# Altium schematic sheet Sheet1.SchDoc(Sheet1)
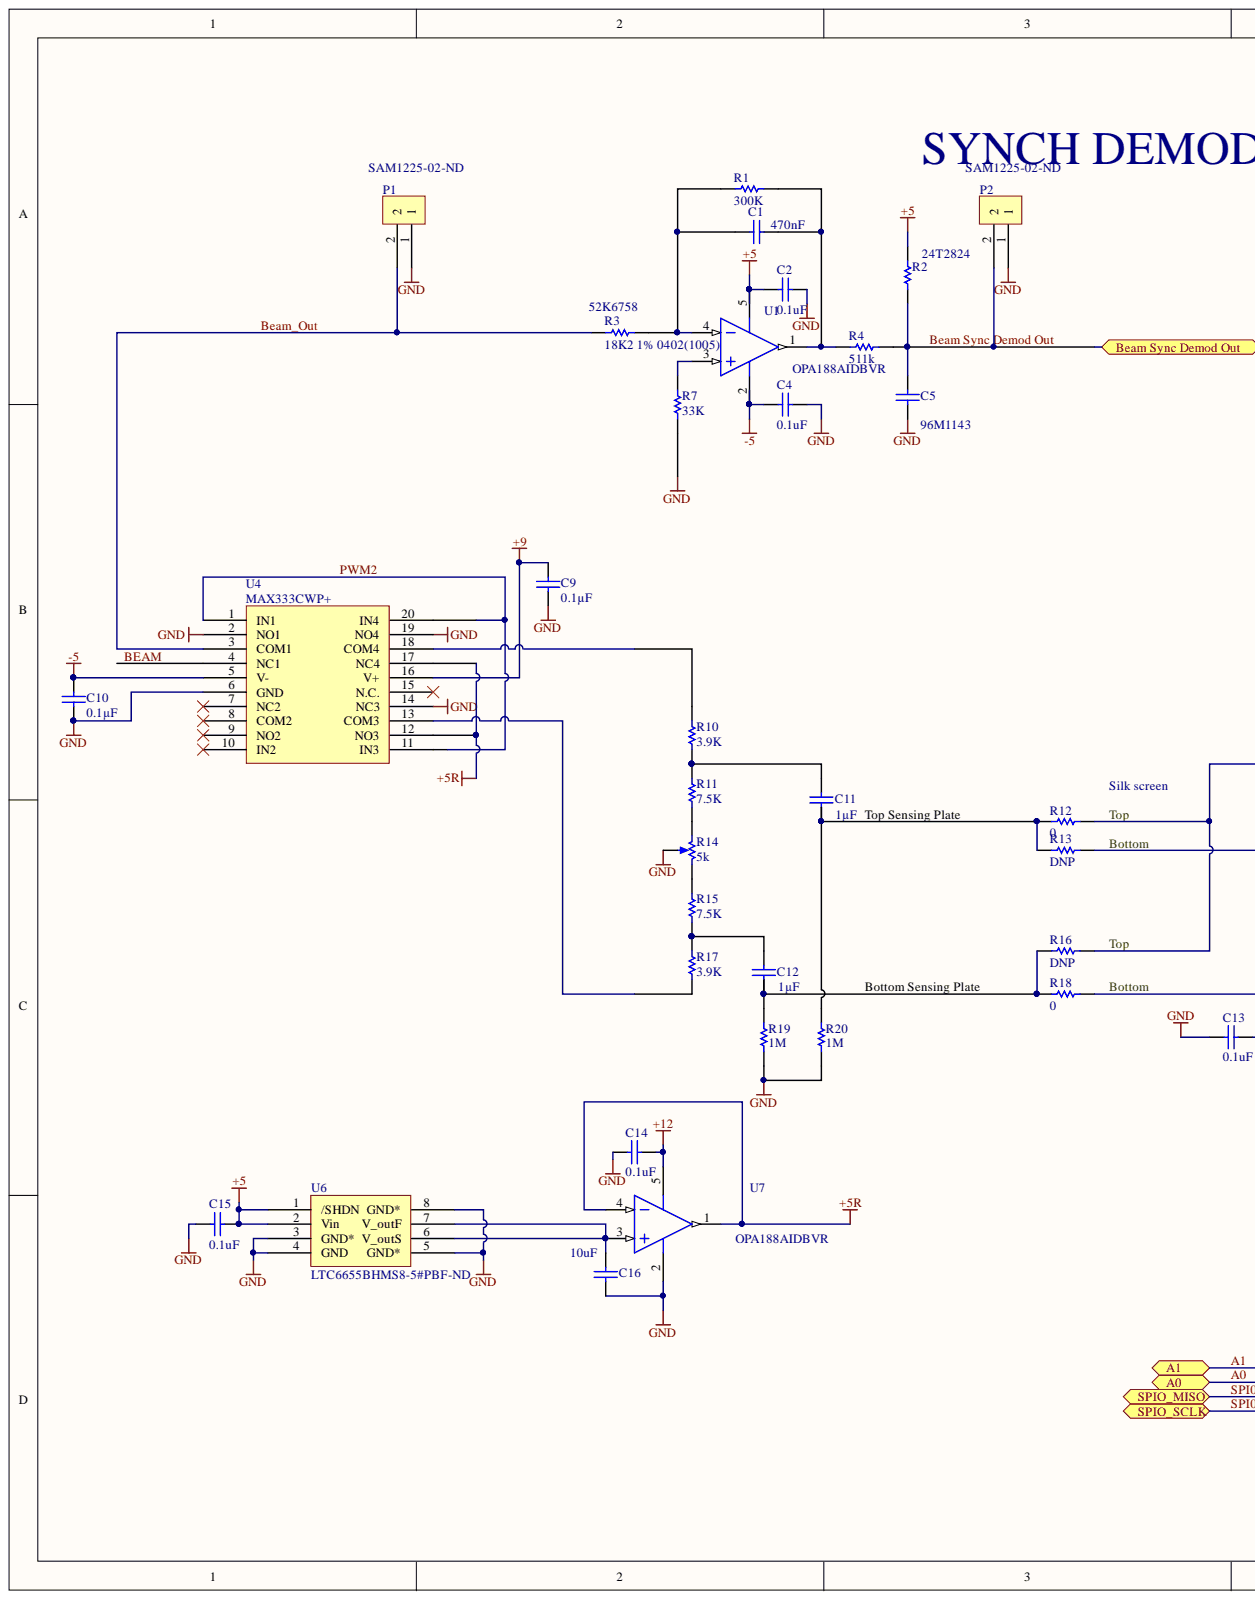
[diagram p0: Sheet1.SchDoc, left]
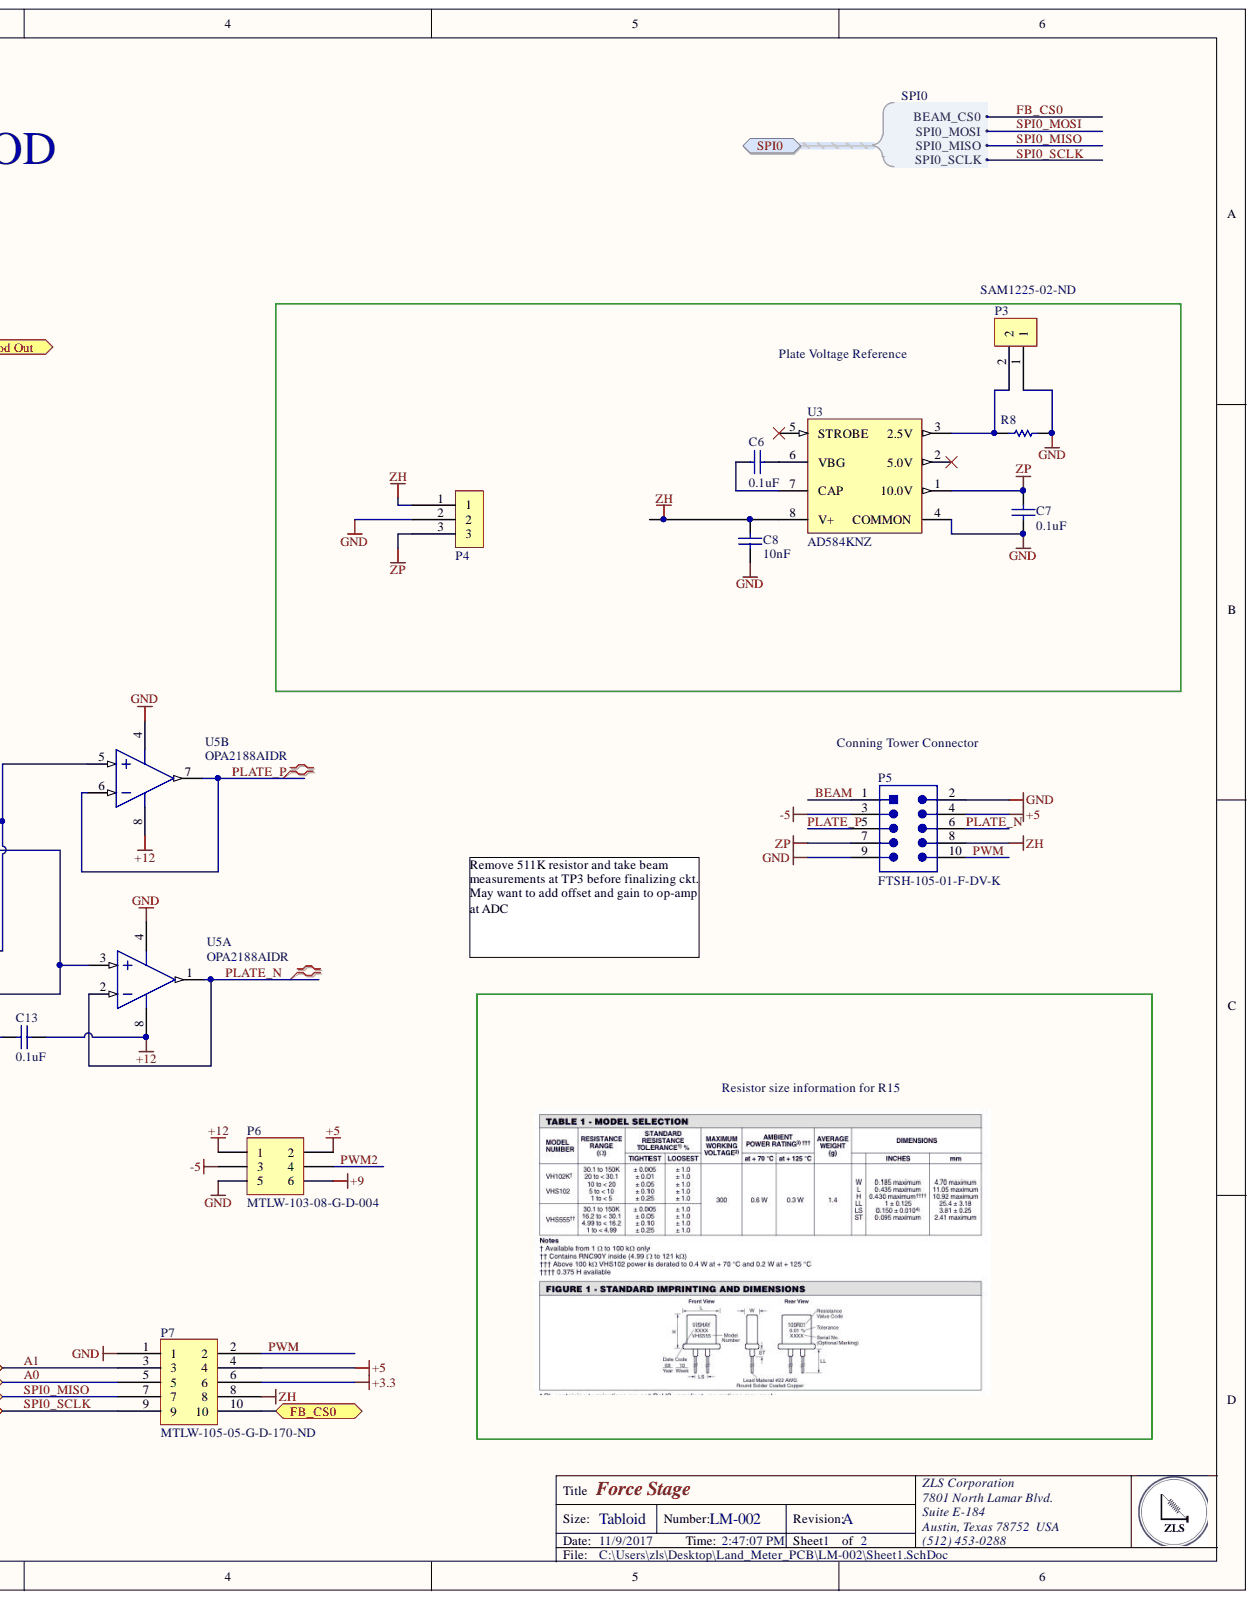
[diagram p1: Sheet1.SchDoc, right]

## Parts
C1  Capacitance: 470nF  [CAPC0402(1005)60_L]
C2  0.1uF  [CAPC0402(1005)60_L]
C4  0.1uF  [CAPC0402(1005)60_L]
C5  Supplier Part Number 1: 96M1143  [CAPC0402(1005)60_L]
C6  0.1uF  [CAPC0402(1005)60_L]
C7  0.1uF  [CAPC0402(1005)60_L]
C8  Capacitance: 10nF  [CAPC0805(2012)145_N]  (hidden comment: Multilayer Ceramic Capacitors MLCC - SMD/SMT 0.01uF 50volts 10% X7R)
C9  Capacitance: 0.1µF  [CAPC0805(2012)145_N]  (hidden comment: CAP CER 0.1UF 25V X7R 0805)
C10  Capacitance: 0.1µF  [CAPC0805(2012)145_N]  (hidden comment: CAP CER 0.1UF 25V X7R 0805)
C11  Capacitance: 1µF  [CAPC0805(2012)155_L]  (hidden comment: CAP CER 1UF 25V X7R 0805)
C12  Capacitance: 1µF  [CAPC0805(2012)155_L]  (hidden comment: CAP CER 1UF 25V X7R 0805)
C13  0.1uF  [CAPC0402(1005)60_L]
C14  0.1uF  [CAPC0402(1005)60_L]
C15  0.1uF  [CAPC0402(1005)60_L]
C16  value 10uF  [CAPC1812(4532)250_N]  (hidden comment: CAP FILM 10UF 20% 16VDC 1812)
P1  Supplier Part Number 1: SAM1225-02-ND  [SSW-102-02-G-S-RA]  (hidden comment: SSW-102-02-G-S-RA)
P2  Supplier Part Number 1: SAM1225-02-ND  [SSW-102-02-G-S-RA]  (hidden comment: SSW-102-02-G-S-RA)
P3  Supplier Part Number 1: SAM1225-02-ND  [SSW-102-02-G-S-RA]  (hidden comment: SSW-102-02-G-S-RA)
P4  SSW-103-02-G-S-RA  [SSW-103-02-G-S-RA]  (value not drawn)
P5  FTSH-105-01-F-DV-K  [SMTC-FTSH-105-01-L-DV-K_V]
P6  MTLW-103-08-G-D-004  [MTLW-103-08-G-D-004]
P7  TLW-105-05-G-D  Supplier Part Number 1: MTLW-105-05-G-D-170-ND  [TLW-105-05-G-D]
R1  300K  [RESC0402(1005)_M]
R2  Supplier Part Number 1: 24T2824  [RESC0402(1005)_M]
R3  18K2 1% 0402(1005)  Supplier Part Number 1: 52K6758  Resistance Tolerance: 1%  [RESC0402(1005)_M]
R4  511k  [RESC0402(1005)_M]
R7  33K  [RESC0402(1005)_M]
R8  TBD  [VH102K]  (value not drawn)
R10  3.9K  [RESC0402(1005)_M]
R11  7.5K  [RESC0402(1005)_M]
R12  0  [RESC0402(1005)_M]
R13  DNP  [RESC0402(1005)_M]
R14  Resistance (Ohms): 5k  [Trimmer 2.54]  (hidden comment: TRIMMER 5K OHM 0.25W PC PIN)
R15  7.5K  [RESC0402(1005)_M]
R16  DNP  [RESC0402(1005)_M]
R17  3.9K  [RESC0402(1005)_M]
R18  0  [RESC0402(1005)_M]
R19  1M  [RESC0402(1005)_M]
R20  1M  [RESC0402(1005)_M]
U1  OPA188AIDBVR  [DBV0005A_M]
U3  AD584KNZ  [ADI-N-8]
U4  MAX333CWP+  [TSOP65P640X90-20N]
U5  OPA2188AIDR  [D0008A_L]  (parts A,B)
U6  LTC6655BHMS8-5#PBF  Supplier Part Number 1: LTC6655BHMS8-5#PBF-ND  [TSOP65P490X110-8N]
U7  OPA188AIDBVR  [DBV0005A_M]

## Ports
A0, A1, Beam Sync Demod Out, FB_CS0, SPI0, SPI0.BEAM_CS0, SPI0.SPI0_MISO, SPI0.SPI0_MOSI, SPI0.SPI0_SCLK, SPIO_MISO, SPIO_SCLK

## Nets
+3.3: P7.6
+5: C2.1 C15.2 P5.4 P6.2 P7.4 R2.2 U1.5 U6.1(/SHDN) U6.2(Vin)
+5R: U4.12(NO3) U4.17(NC4) U7.1 U7.4
+9: C9.2 P6.6 U4.16(V+)
+12: C13.2 C14.2 P6.1 U5.8 U7.5
-5: C4.1 C10.1 P5.3 P6.3 U1.2 U4.5(V-)
GND: C2.2 C4.2 C5.1 C7.1 C8.2 C9.1 C10.2 C13.1 C14.1 C15.1 C16.1 P1.1 P2.1 P3.1 P4.2 P5.2 P5.9 P6.5 P7.1 R7.1 R8.2 R14.2 R19.1 R20.1 U3.4(COMMON) U4.2(NO1) U4.6(GND) U4.14(NC3) U4.19(NO4) U5.4 U6.3(GND*) U6.4(GND) U6.5(GND*) U6.8(GND*) U7.2
ZH: C8.1 P4.1 P5.8 P7.8 U3.8(V+)
ZP: C7.2 P4.3 P5.7 U3.1(10.0V)
A0: P7.5 ; port A0
A1: P7.3 ; port A1
BEAM: P5.1 U4.4(NC1)
Beam Sync Demod Out: C5.2 P2.2 R2.1 R4.2 ; port Beam Sync Demod Out
Beam_Out: P1.2 R3.1 U4.3(COM1)
FB_CS0: P7.10 ; port FB_CS0
PLATE_N: P5.6 U5.1 U5.2
PLATE_P: P5.5 U5.6 U5.7
PWM: P5.10 P7.2
PWM2: P6.4 U4.1(IN1) U4.11(IN3) U4.20(IN4)
SPI0_MISO: P7.7 ; port SPI0, port SPIO_MISO
SPI0_SCLK: P7.9 ; port SPI0, port SPIO_SCLK
NetC1_1: C1.1 R1.1 R3.2 U1.4
NetC1_2: C1.2 R1.2 R4.1 U1.1
NetC6_1: C6.1 U3.7(CAP)
NetC6_2: C6.2 U3.6(VBG)
NetC11_1: C11.1 R12.2 R13.2 R20.2
NetC11_2: C11.2 R10.1 R11.2
NetC12_1: C12.1 R16.2 R18.2 R19.2
NetC12_2: C12.2 R15.1 R17.2
NetC16_2: C16.2 U6.6(V_outS) U6.7(V_outF) U7.3
NetP3_2: P3.2 R8.1 U3.3(2.5V)
NetR7_2: R7.2 U1.3
NetR10_2: R10.2 U4.18(COM4)
NetR11_1: R11.1 R14.1
NetR12_1: R12.1 R16.1 U5.5
NetR13_1: R13.1 R18.1 U5.3
NetR14_3: R14.3 R15.2
NetR17_1: R17.1 U4.13(COM3)
FB_CS0: ; port SPI0
SPI0_MOSI: ; port SPI0

Not connected: U3 2(5.0V) 5(STROBE); U4 7(NC2) 8(COM2) 9(NO2) 10(IN2) 15(N.C.)

## Library data of the parts
C1 = CMP-1034-01343-1: Manufacturer Part Number: C1005X5R1E474M050BB; Manufacturer: TDK; Voltage Rating (DC): 25V; Tolerance: 20%; Dielectric Material: Ceramic; Dielectric: X5R; Width: 0.02inch; Weight: 2.3E-05oz; Voltage Rating: 25V; Thickness: 500um; Termination: SMD/SMT; Supplier Part Number 1: 810-C1005X5R1E474M; Supplier 1: Mouser; Stock 1: 40105; REACH SVHC: No SVHC; Radiation Hardening: No; Pricing 1: 1=0.15, 10=0.101, 50=0.101, 100=0.04, 1000=0.028, 10000=0.023 (USD); Packaging: Tape and Reel; Package Quantity: 10000; Mount: Surface Mount; Min Operating Temperature: -55°C; Max Operating Temperature: 85°C; Library Name: Altium Content Vault; Length: 1mm; Lead Free: Lead Free; Height: 0.5mm; Depth: 0.5mm; Composition: Ceramic; ComponentLink2URL: https://datasheet.ciiva.com/24219/mlcc-commercial-general-en-24219290.pdf; ComponentLink2Description: https://datasheet.ciiva.com/24219/mlcc-commercial-general-en-24219290.pdf; ComponentLink1URL: https://datasheet.ciiva.com/26870/getdatasheetpartid-753870-26870164.pdf; ComponentLink1Description: https://datasheet.ciiva.com/26870/getdatasheetpartid-753870-26870164.pdf; Component Kind: Standard; Case Code (Metric): 1005; Case Code (Imperial): 0402; Case/Package: 0402
C2 C4 C6 C7 C13-C15 = CMP-1034-00252-1: CAP CER 0.1UF 10V X7R 0402; Manufacturer Part Number: GRM155R71A104KA01D; Manufacturer: Murata Electronics North America; Tolerance: ±10%; Voltage - Rated: 10V; Thickness (Max): 0.022" (0.55mm); Temperature Coefficient: X7R; Supplier Part Number 1: 490-6321-1-ND; Supplier 1: Digi-Key; Stock 1: 2920395; Size / Dimension: 0.039" L x 0.020" W (1.00mm x 0.50mm); RoHS: RoHS Compliant; Ratings: -; Pricing 1: 1=0.1, 10=0.014, 100=0.0063, 500=0.00446, 1000=0.00351, 2500=0.00319, 5000=0.00293 (USD); Part Status: Active; Packaging: Cut Tape (CT); Package / Case: 0402 (1005 Metric); Operating Temperature: -55°C ~ 125°C; Mounting Type: Surface Mount, MLCC; Library Name: Altium Content Vault; Lead Spacing: -; Height - Seated (Max): -; Features: -; ComponentLink1URL: http://www.murata.com/~/media/webrenewal/support/library/catalog/products/capacitor/mlcc/c02e.ashx?la=en-us; ComponentLink1Description: http://www.murata.com/~/media/webrenewal/support/library/catalog/products/capacitor/mlcc/c02e.ashx?la=en-us; Component Kind: Standard; Category: Capacitors; Applications: General Purpose
C5 = CMP-1034-01343-1: Manufacturer Part Number: 04025A220JAT2A; Manufacturer: AVX; Voltage Rating: 50; Dielectric Characteristic: C0G / NP0; Ceramic Capacitor Case: 0402 [1005 Metric]; Capacitance Tolerance: 5%; Capacitance: 22; Supplier 1: Newark; Stock 1: 239391; RoHS: YES; Product Range: -; Pricing 1: 1=0.003, 25=0.003, 100=0.003, 250=0.003, 500=0.003, 1000=0.003, 2500=0.003 (USD); Packaging: Cut Tape; Library Name: Altium Content Vault; ComponentLink1URL: http://www.farnell.com/datasheets/2068140.pdf; ComponentLink1Description: http://www.farnell.com/datasheets/2068140.pdf; Component Kind: Standard
C8 = CMP-1036-04795-1: Manufacturer Part Number: C0805C103K5RACAUTO; Manufacturer: Kemet; Voltage Rating (DC): 50V; Width: 0.049inch; Voltage Rating: 50V; Tolerance: 10%; Thickness: 0.035inch; Termination: SMD/SMT; Supplier Part Number 1: 399-6924-1-ND; Supplier 1: Digi-Key; Stock 1: 63236; REACH SVHC: No SVHC; Radiation Hardening: No; Pricing 1: 1=0.12, 10=0.087, 100=0.041, 500=0.0287, 1000=0.0238 (USD); Packaging: Tape and Reel; Package Quantity: 1; Mount: Surface Mount; Min Operating Temperature: -55°C; Max Operating Temperature: 125°C; Library Name: Altium Content Vault; Length: 2mm; Height: 0.78mm; Dielectric Material: Ceramic; Dielectric: X7R; Description: Multilayer Ceramic Capacitors MLCC - SMD/SMT 0.01uF 50volts 10% X7R; Depth: 1.25mm; Contact Plating: Tin; ComponentLink2URL: https://datasheet.ciiva.com/7842/1693454-7842175.pdf; ComponentLink2Description: https://datasheet.ciiva.com/7842/1693454-7842175.pdf; ComponentLink1URL: https://datasheet.ciiva.com/22700/1693454-22700519.pdf; ComponentLink1Description: https://datasheet.ciiva.com/22700/1693454-22700519.pdf; Component Kind: Standard; Case Code (Metric): 2012; Case Code (Imperial): 0805; Case/Package: 0805
C9 C10 = CMP-1036-04795-1: Manufacturer Part Number: GRM21BR71E104KA01L; Manufacturer: Murata Electronics North America; Tolerance: ±10%; Voltage - Rated: 25V; Thickness (Max): 0.053" (1.35mm); Temperature Coefficient: X7R; Supplier Part Number 1: 490-1673-6-ND; Supplier 1: Digi-Key; Stock 1: 891652; Size / Dimension: 0.079" L x 0.049" W (2.00mm x 1.25mm); RoHS: RoHS Compliant; Ratings: -; Pricing 1: 1=0.2, 10=0.145, 100=0.0683, 500=0.04788, 1000=0.03964 (USD); Part Status: Active; Packaging: Digi-Reel®; Package / Case: 0805 (2012 Metric); Operating Temperature: -55°C ~ 125°C; Mounting Type: Surface Mount, MLCC; Library Name: Altium Content Vault; Lead Style: -; Lead Spacing: -; Height - Seated (Max): -; Features: -; Description: CAP CER 0.1UF 25V X7R 0805; ComponentLink1URL: http://www.murata.com/~/media/webrenewal/support/library/catalog/products/capacitor/mlcc/c02e.ashx; ComponentLink1Description: http://www.murata.com/~/media/webrenewal/support/library/catalog/products/capacitor/mlcc/c02e.ashx; Component Kind: Standard; Category: Capacitors; Applications: General Purpose
C11 C12 = CMP-1036-04882-1: Manufacturer Part Number: GRM21BR71E105KA99L; Manufacturer: Murata Electronics North America; Tolerance: ±10%; Voltage - Rated: 25V; Thickness (Max): 0.053" (1.35mm); Temperature Coefficient: X7R; Supplier Part Number 1: 490-6484-6-ND; Supplier 1: Digi-Key; Stock 1: 295074; Size / Dimension: 0.079" L x 0.049" W (2.00mm x 1.25mm); RoHS: RoHS Compliant; Ratings: -; Pricing 1: 1=0.14, 10=0.099, 100=0.0468, 500=0.0328, 1000=0.02715 (USD); Part Status: Active; Packaging: Digi-Reel®; Package / Case: 0805 (2012 Metric); Operating Temperature: -55°C ~ 125°C; Mounting Type: Surface Mount, MLCC; Library Name: Altium Content Vault; Lead Style: -; Lead Spacing: -; Height - Seated (Max): -; Features: -; Description: CAP CER 1UF 25V X7R 0805; ComponentLink1URL: http://www.murata.com/~/media/webrenewal/support/library/catalog/products/capacitor/mlcc/c02e.ashx; ComponentLink1Description: http://www.murata.com/~/media/webrenewal/support/library/catalog/products/capacitor/mlcc/c02e.ashx; Component Kind: Standard; Category: Capacitors; Applications: General Purpose
C16 = CMP-1040-01653-1: Manufacturer Part Number: 16ST106MC44532; Manufacturer: Rubycon; Voltage Rating - DC: 16V; Tolerance: Â±10%; Rated Voltage: 16 V; Dielectric Material: Acrylic, Metallized; Case-Metric: 4532; Case-EIA: 1812; Voltage Rating - AC: -; Technology: SMT; Supplier Part Number 1: 1189-1792-1-ND; Supplier 1: Digi-Key; Stock 1: 1994; Size / Dimension: 0.177" L x 0.126" W (4.50mm x 3.20mm); RoHS: RoHS Compliant; Pricing 1: 1=7.05, 10=6.263, 100=5.0105 (USD); Part Status: Active; Packaging: Cut Tape (CT); Package / Case: 1812 (4532 Metric); Operating Temperature: -55°C ~ 125°C; Mounting Type: Surface Mount; Max Thickness: 2.5 mm; Library Name: Altium Content Vault; Lead Spacing: -; Height - Seated (Max): 0.102" (2.60mm); Features: -; Description: CAP FILM 10UF 20% 16VDC 1812; ComponentLink1URL: http://www.rubycon.co.jp/en/catalog/e_pdfs/pmlcap/e_ST.pdf; ComponentLink1Description: http://www.rubycon.co.jp/en/catalog/e_pdfs/pmlcap/e_ST.pdf; Component Kind: Standard; Category: Capacitors; Applications: DC Link, DC Filtering
P5 = CMP-2000-05352-1: Male Header, Pitch 1.27 mm, 2 x 5 Position, Height 6.12 mm, RoHS, Tube; Manufacturer: Samtec; Number of Positions Loaded: All; Number of Positions: 10; Connector Type: Header,Shrouded; Termination: Solder; Series: FTSH; Packaging: Tube; Package URL: http://suddendocs.samtec.com/prints/ftsh-1xx-xx-xxx-dv-xxx-footprint.pdf; Package Reference: FTSH-105-01-L-DV-K; Package Description: Male Header, Pitch 1.27 mm, 2 x 5 Position, Height 5.97 mm, Tail Length 4.32 mm; Number of Rows: 2; Mounting Type: SurfaceMount; Manufacturer URL: http://www.samtec.com/; Library Name: Altium Content Vault; Features: -; Fastening Type: -; Family: RectangularConnectors-Headers,MalePins; Datasheet Version: Rev. ET, 10/1995; Datasheet URL: http://suddendocs.samtec.com/prints/ftsh-1xx-xx-xxx-dv-xxx-mkt.pdf; Contact Type: MalePin; Contact Finish Thickness: 3µin(0.08µm); Contact Finish: Gold; Component Kind: Standard; Color: Black; Category: Connectors,Interconnects
P6 = Header 3X2: Header, 3-Pin, Dual row; Signal Integrity: Connector; Library Name: Miscellaneous Connectors.IntLib; Component Kind: Standard
P7 = TLW-105-05-G-D: Manufacturer Part Number: MTLW-105-05-G-D-170; Manufacturer: Samtec Inc.; Style: Board to Board or Cable; Shrouding: Unshrouded; Row Spacing - Mating: 0.100" (2.54mm); Overall Contact Length: 0.335" (8.51mm); Number of Positions Loaded: All; Number of Positions: 10; Material Flammability Rating: UL94 V-0; Current Rating: 5.2A per Contact; Contact Length - Post: 0.105" (2.67mm); Contact Length - Mating: 0.170" (4.32mm); Connector Type: Header; Voltage Rating: -; Termination: Solder; Supplier 1: Digi-Key; Stock 1: 0; RoHS: RoHS Compliant; Pricing 1: 1=1.79 (USD); Pitch - Mating: 0.100" (2.54mm); Part Status: Active; Packaging: Tube; Operating Temperature: -55°C ~ 125°C; Number of Rows: 2; Mounting Type: Through Hole; Mated Stacking Heights: -; Insulation Material: Liquid Crystal Polymer (LCP); Insulation Height: 0.060" (1.52mm); Insulation Color: Black; Ingress Protection: -; Features: -; Fastening Type: Push-Pull; Contact Type: Male Pin; Contact Shape: Square; Contact Material: Phosphor Bronze; Contact Finish Thickness - Post: 3µin (0.08µm); Contact Finish Thickness - Mating: 10µin (0.25µm); Contact Finish - Post: Gold; Contact Finish - Mating: Gold; ComponentLink1URL: http://suddendocs.samtec.com/prints/mtlw-1xx-xx-xx-x-xxx-xx-xx-mkt1.pdf; ComponentLink1Description: http://suddendocs.samtec.com/prints/mtlw-1xx-xx-xx-x-xxx-xx-xx-mkt1.pdf; Component Kind: Standard; Category: Connectors, Interconnects; Applications: -; Library Name: ZLS_Schematic_lib.SchLib
R1 R4 R7 R12 R13 R16 R18 = CMP-1011-00651-1: Library Name: Altium Content Vault; Component Kind: Standard
R2 = CMP-1011-00651-1: Manufacturer Part Number: CRCW0402100KFKEDHP; Manufacturer: VISHAY; Supplier 1: Newark; Stock 1: 0; Pricing 1: 10000=0.014, 20000=0.012 (USD); Library Name: Altium Content Vault; ComponentLink1URL: http://www.farnell.com/datasheets/2140397.pdf; ComponentLink1Description: http://www.farnell.com/datasheets/2140397.pdf; Component Kind: Standard
R3 = CMP-1011-00651-1: 18K2 0.063W 1% 0402 (1005 Metric)  SMD; Manufacturer Part Number: CRCW040218K2FKED; Manufacturer: VISHAY; Voltage Rating: 50; Resistor Case Style: 0402 [1005 Metric]; Resistance: 18.2; Product Range: CRCW Series; Power Rating: 63; No. of Pins: 2; Supplier 1: Newark; Stock 1: 9263; RoHS: YESX; Pricing 1: 1=0.004, 25=0.004, 100=0.004, 250=0.004, 500=0.004, 1000=0.004 (USD); Packaging: Cut Tape; Library Name: Altium Content Vault; ComponentLink3URL: http://www.farnell.com/datasheets/2111203.pdf?_ga=1.39057253.733356815.1493180800; ComponentLink3Description: http://www.farnell.com/datasheets/2111203.pdf?_ga=1.39057253.733356815.1493180800; ComponentLink2URL: http://www.farnell.com/cad/1551401.zip; ComponentLink2Description: http://www.farnell.com/cad/1551401.zip; ComponentLink1URL: http://www.vishay.com/docs/31543/packsmre.pdf; ComponentLink1Description: http://www.vishay.com/docs/31543/packsmre.pdf; Component Kind: Standard
R8 = CMP-1013-00393-1: Component Kind: Standard
R10 R11 R15 R17 R19 R20 = CMP-1011-00463-1: Library Name: Altium Content Vault; Component Kind: Standard
R14 = Trimmer: Manufacturer Part Number: Y00535K00000J0L; Manufacturer: Vishay Foil Resistors (Division of Vishay Precision Group); Tolerance: ±5%; Temperature Coefficient: ±10ppm/°C; Resistive Material: Metal Foil; Power (Watts): 0.25W, 1/4W; Number of Turns: 21; Adjustment Type: Side Adjustment; Termination Style: PC Pins; Supplier Part Number 1: 804-1020-ND; Supplier 1: Digi-Key; Stock 1: 679; Size / Dimension: Rectangular - 0.250" x 0.175" Face x 0.250" L (6.35mm x 4.45mm x 6.35mm); RoHS: RoHS Compliant; Pricing 1: 1=34.42, 10=32.265, 25=26.5292, 50=22.944, 100=22.227, 250=20.793, 500=19.2156 (USD); Part Status: Active; Packaging: Bulk; Mounting Type: Through Hole; Description: TRIMMER 5K OHM 0.25W PC PIN; ComponentLink1URL: http://www.vishaypg.com/docs/63053/1240.pdf; ComponentLink1Description: http://www.vishaypg.com/docs/63053/1240.pdf; Component Kind: Standard; Category: Potentiometers, Variable Resistors; Library Name: ZLS_Schematic_lib.SchLib
U1 U7 = CMP-0809-00005-2: Precision, Low Noise, Rail-to-Rail Output, Zero-Drift Operational Amplifier, 4 to 36 V, -40 to 125 degC, 5-pin SOT23 (DBV5), Green (RoHS & no Sb/Br); Manufacturer: Texas Instruments; Total Supply Voltage(Min)(+5V is 5, +/-5V is 10): 4; Total Supply Voltage(Max)(+5V is 5, +/-5V is 10): 36; SubFamily: Zero Drift Precision Amplifier; Slew Rate(Typ)(V/us): 0.8; Rating: Catalog; Offset Drift(Typ)(uV/C): 0.03; Number of Channels: 1; Iq per channel(Max)(mA): 0.51; GBW(Typ)(MHz): 2; CMRR(Min)(dB): 120; PartNumber: OPA188AIDBVR; PackageVersion: 4073253-4/K, 03/2006; PackageReference: DBV0005A; PackageDescription: 5-Pin Plastic Small Outline, Body 1.6 x 2.9 mm, Pitch 0.95 mm; Mounting Technology: Surface Mount; Library Name: Altium Content Vault; Eco-Plan: Green (RoHS & no Sb/Br); DatasheetVersion: SBOS642A; ComponentLink3URL: http://www.ti.com/litv/pdf/mpds018i; ComponentLink3Description: Package Specification; ComponentLink2URL: http://www.ti.com/lit/ds/symlink/opa188.pdf; ComponentLink2Description: Datasheet; ComponentLink1URL: http://www.ti.com/; ComponentLink1Description: Manufacturer URL; Component Kind: Standard; Code_JEDEC: MO-178
U3 = CMP-0784-00054-1: Pin Programmable, Precision Voltage Reference, + 2.5 / + 5 / + 7.5 / + 10 Prog V Output, 4.5 to 30 V Supply, Commercial, 8-pin DIP (N-8), Tube; Manufacturer: Analog Devices; Vout: 2.5V, 5V, 7.5V, 10V; Ref Out TC (ppm/C): 15; Min V Supply (V): 4.5; Max V Supply (V): 30; PartNumber: AD584KNZ; Packing: Tube; PackageVersion: 070606-A, 07/2006; PackageReference: N-8; PackageDescription: 8-Pin Plastic Dual In-Line Package, Row spacing 7.62 mm, Pitch 2.54 mm; Operating Temp Range: 0 to 70 degC; Mounting Technology: Through Hole; Library Name: Altium Content Vault; DatasheetVersion: Rev. C; ComponentLink4URL: Product URL:http://www.analog.com/en/special-linear-functions/voltage-references/ad584/products/product.html; ComponentLink4Description: URL Link1; ComponentLink3URL: http:/www.analog.com/static/imported-files/packages/PKG_PDF/PDIP(N)/N_8.pdf; ComponentLink3Description: Package Specification; ComponentLink2URL: http://www.analog.com/static/imported-files/data_sheets/AD584.pdf; ComponentLink2Description: Datasheet; ComponentLink1URL: http://www.analog.com/en/index.html; ComponentLink1Description: Manufacturer URL; Component Kind: Standard; Code_JEDEC: MS-001
U4 = MAX333CWP+: IC SWITCH QUAD SPDT 20TSSOP; Manufacturer Part Number: MAX333AEUP+; Manufacturer: Maxim; Turn-On Delay Time: 175ns; Turn-Off Delay Time: 145ns; Supply Type: Single,Dual; Operating Supply Current: 1uA; On-State Resistance: 35R; Number of Channels: 4; Min Supply Voltage: 10V; Min Dual Supply Voltage: 4.5V; Max Supply Voltage: 30V; Max Supply Current: 250uA; Max Power Dissipation: 559mW; Max Dual Supply Voltage: 20V; Dual Supply Voltage: 15V; Width: 4.5mm; Weight: 0.006737oz; Throw Configuration: SPDT; Supplier Part Number 1: 700-MAX333AEUP; Supplier 1: Mouser; Stock 1: 664; REACH SVHC: Unknown; Radiation Hardening: No; Pricing 1: 1=6.77, 10=6.43, 50=5.8, 100=5.8, 1000=5.62, 10000=5.62 (USD); Packaging: Rail/Tube; Package Quantity: 74; Number of Pins: 20; Number of Bits: 4; Min Operating Temperature: -40°C; Max Operating Temperature: 85°C; Length: 6.6mm; Lead Free: Lead Free; Height: 0.95mm; ComponentLink4URL: https://datasheet.ciiva.com/26825/max333aeup-26825546.pdf; ComponentLink4Description: https://datasheet.ciiva.com/26825/max333aeup-26825546.pdf; ComponentLink3URL: https://datasheet.ciiva.com/1674/max333a-1674617.pdf; ComponentLink3Description: https://datasheet.ciiva.com/1674/max333a-1674617.pdf; ComponentLink2URL: https://datasheet.ciiva.com/30478/0900766b81253c85-30478260.pdf; ComponentLink2Description: https://datasheet.ciiva.com/30478/0900766b81253c85-30478260.pdf; ComponentLink1URL: https://datasheet.ciiva.com/2978/max333a-2978850.pdf; ComponentLink1Description: https://datasheet.ciiva.com/2978/max333a-2978850.pdf; Component Kind: Standard; Case/Package: TSSOP; Library Name: ZLS_Schematic_lib.SchLib
U5 = CMP-0272-00241-3: 0.03 uV/degC, 6 uV Vos, Low Noise, Rail-to-Rail Output, Zero-Drift Operational Amplifier, 4 to 36 V, -40 to 105 degC, 8-pin SOIC (D8), Green (RoHS & no Sb/Br); Manufacturer: Texas Instruments; Voltage - Supply, Single/Dual (±): 4 V ~ 36 V, ±2 V ~ 18 V; Slew Rate: 0.8 V/µs; Number of Circuits: 2; Current - Supply: 415µA; Current - Output / Channel: 18mA; Supplier Part Number 1: 296-29454-6-ND; Supplier Device Package: 8-SOIC; Supplier 1: Digi-Key; Stock 1: 8272; RoHS: RoHS Compliant; Pricing 1: 1=3.44, 10=3.089, 100=2.5307, 500=2.15434, 1000=1.81692 (USD); Part Status: Active; Packaging: Digi-Reel®; Package / Case: 8-SOIC (0.154", 3.90mm Width); Output Type: Rail-to-Rail; Operating Temperature: -40°C ~ 105°C; Mounting Type: Surface Mount; Manufacturer Part Number: OPA2188AIDR; Library Name: Altium Content Vault; ComponentLink1URL: http://www.ti.com/lit/ds/symlink/opa2188.pdf; ComponentLink1Description: http://www.ti.com/lit/ds/symlink/opa2188.pdf; Component Kind: Standard; Category: Integrated Circuits (ICs); Amplifier Type: Zero-Drift; -3db Bandwidth: -
U6 = LTC6655BHMS8-5#PBF: Manufacturer: Linear Technology; Voltage - Output (Min/Fixed): 5V; Voltage - Input: 5.5 V ~ 13.2 V; Tolerance: ±0.025%; Temperature Coefficient: 2ppm/°C; Reference Type: Series; Output Type: Fixed; Noise - 0.1Hz to 10Hz: 0.25ppmp-p; Current - Supply: 7.5mA; Supplier Device Package: 8-MSOP; Supplier 1: Digi-Key; Stock 1: 872; RoHS: RoHS Compliant; Pricing 1: 1=12.29, 25=8.158, 100=6.7 (USD); Part Status: Active; Packaging: Tube; Package / Case: 8-TSSOP, 8-MSOP (0.118", 3.00mm Width); Operating Temperature: -40°C ~ 125°C (TA); Noise - 10Hz to 10kHz: -; Mounting Type: Surface Mount; Manufacturer Part Number: LTC6655BHMS8-5#PBF; ComponentLink1URL: http://www.linear.com/docs/28576; ComponentLink1Description: http://www.linear.com/docs/28576; Component Kind: Standard; Category: Integrated Circuits (ICs); Library Name: ZLS_Schematic_lib.SchLib
P1-P3 = SSW-102-02-G-S-RA: Supplier 1: Digi-Key; Component Kind: Standard; Library Name: ZLS_Schematic_lib.SchLib
P4 = SSW-103-02-G-S-RA: Component Kind: Standard; Library Name: ZLS_Schematic_lib.SchLib
